# Altium schematic sheet nixie-board-b.SchDoc
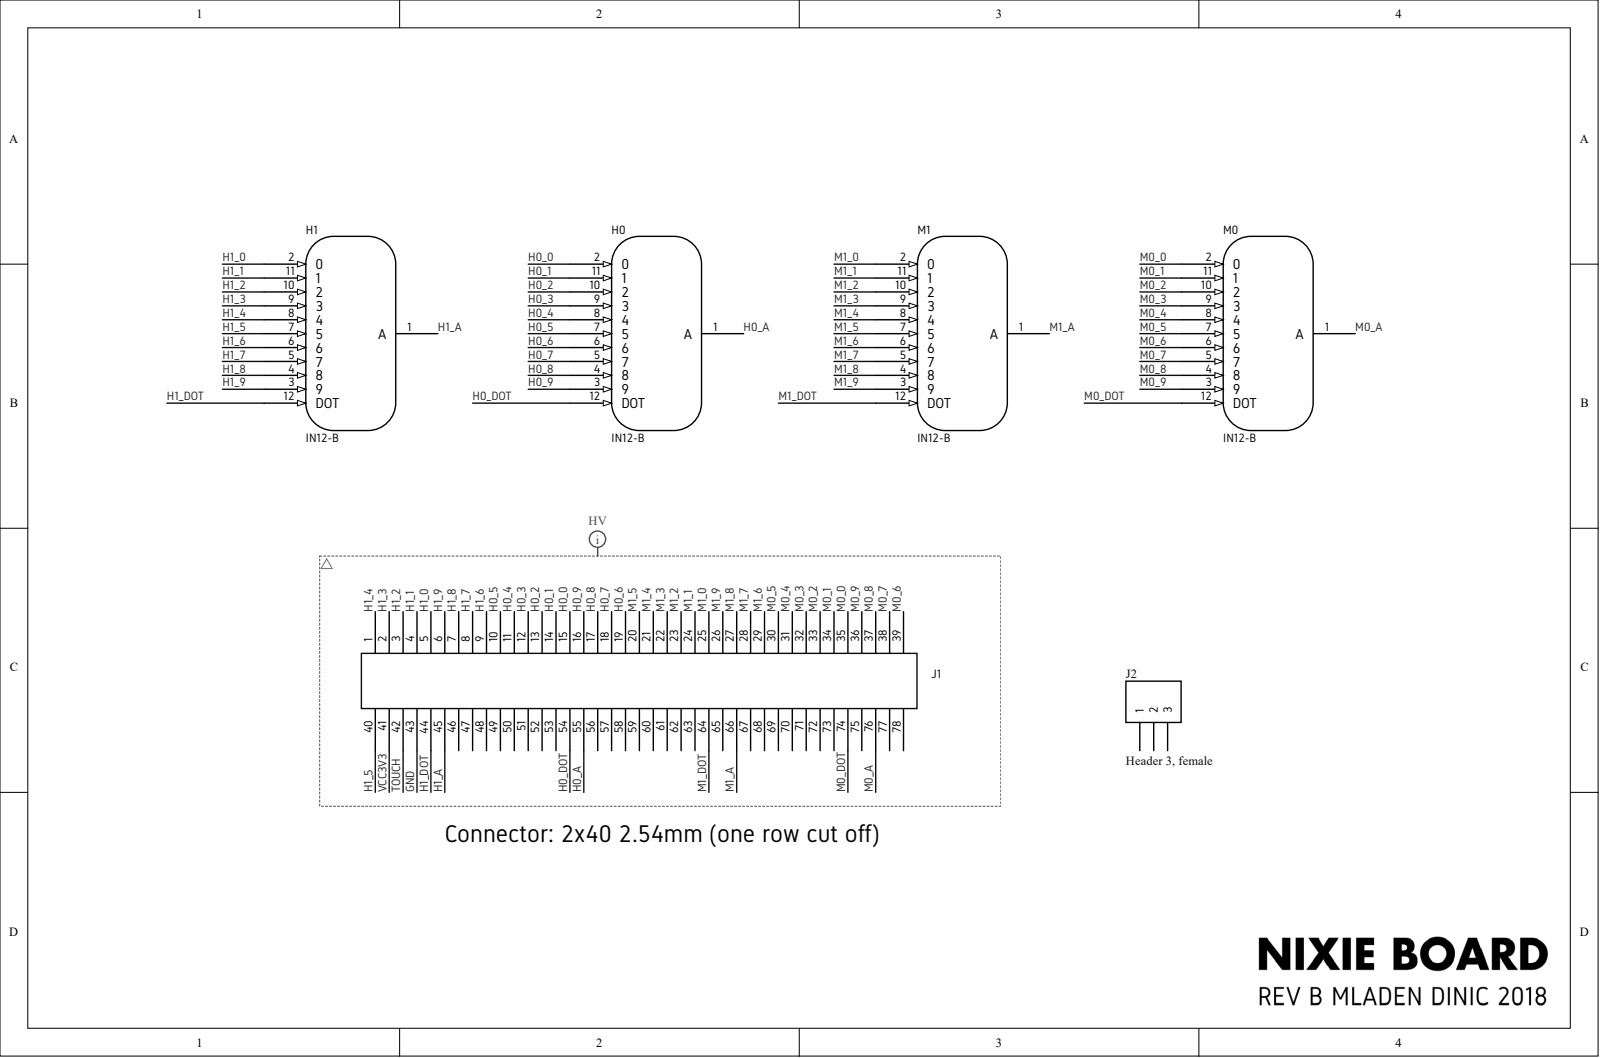
[diagram p0: nixie-board-b.SchDoc]

## Parts
H0  IN12-B  [IN-12]
H1  IN12-B  [IN-12]
J1  Header 2x39 M  [Header 2x39M]  (value not drawn)
J2  Header 3, female  [HDR1X3 FEMALE]
M0  IN12-B  [IN-12]
M1  IN12-B  [IN-12]

## Nets
GND: J1.43
H0_0: H0.2 J1.15
H0_1: H0.11 J1.14
H0_2: H0.10 J1.13
H0_3: H0.9 J1.12
H0_4: H0.8 J1.11
H0_5: H0.7 J1.10
H0_6: H0.6 J1.19
H0_7: H0.5 J1.18
H0_8: H0.4 J1.17
H0_9: H0.3 J1.16
H0_A: H0.1(A) J1.55
H0_DOT: H0.12(DOT) J1.54
H1_0: H1.2 J1.5
H1_1: H1.11 J1.4
H1_2: H1.10 J1.3
H1_3: H1.9 J1.2
H1_4: H1.8 J1.1
H1_5: H1.7 J1.40
H1_6: H1.6 J1.9
H1_7: H1.5 J1.8
H1_8: H1.4 J1.7
H1_9: H1.3 J1.6
H1_A: H1.1(A) J1.45
H1_DOT: H1.12(DOT) J1.44
M0_0: J1.35 M0.2
M0_1: J1.34 M0.11
M0_2: J1.33 M0.10
M0_3: J1.32 M0.9
M0_4: J1.31 M0.8
M0_5: J1.30 M0.7
M0_6: J1.39 M0.6
M0_7: J1.38 M0.5
M0_8: J1.37 M0.4
M0_9: J1.36 M0.3
M0_A: J1.76 M0.1(A)
M0_DOT: J1.74 M0.12(DOT)
M1_0: J1.25 M1.2
M1_1: J1.24 M1.11
M1_2: J1.23 M1.10
M1_3: J1.22 M1.9
M1_4: J1.21 M1.8
M1_5: J1.20 M1.7
M1_6: J1.29 M1.6
M1_7: J1.28 M1.5
M1_8: J1.27 M1.4
M1_9: J1.26 M1.3
M1_A: J1.66 M1.1(A)
M1_DOT: J1.64 M1.12(DOT)
TOUCH: J1.42
VCC3V3: J1.41
N00050:

Not connected: J1 46 47 48 49 50 51 52 53 56 57 58 59 60 61 62 63 65 67 68 69 70 71 72 73 75 77 78; J2 1 2 3

## Library data of the parts
H0 H1 M0 M1 = IN-12B: Nixie Tube; Component Kind: Standard; Library Name: Biblioteka-TH.SchLib
J1 = Header 2x39: Header, 2x39 (made from 2x40); Component Kind: Standard; Signal Integrity: Connector; Supplier 1: TME; Supplier Part Number 1: ZL301-2X40P; Library Name: Biblioteka-TH.SchLib
J2 = Header 3, female: Header, 3-Pin, female; Component Kind: Standard; Library Name: Biblioteka-TH.SchLib
